# Altium schematic sheet mcu.SchDoc(U_mcu)
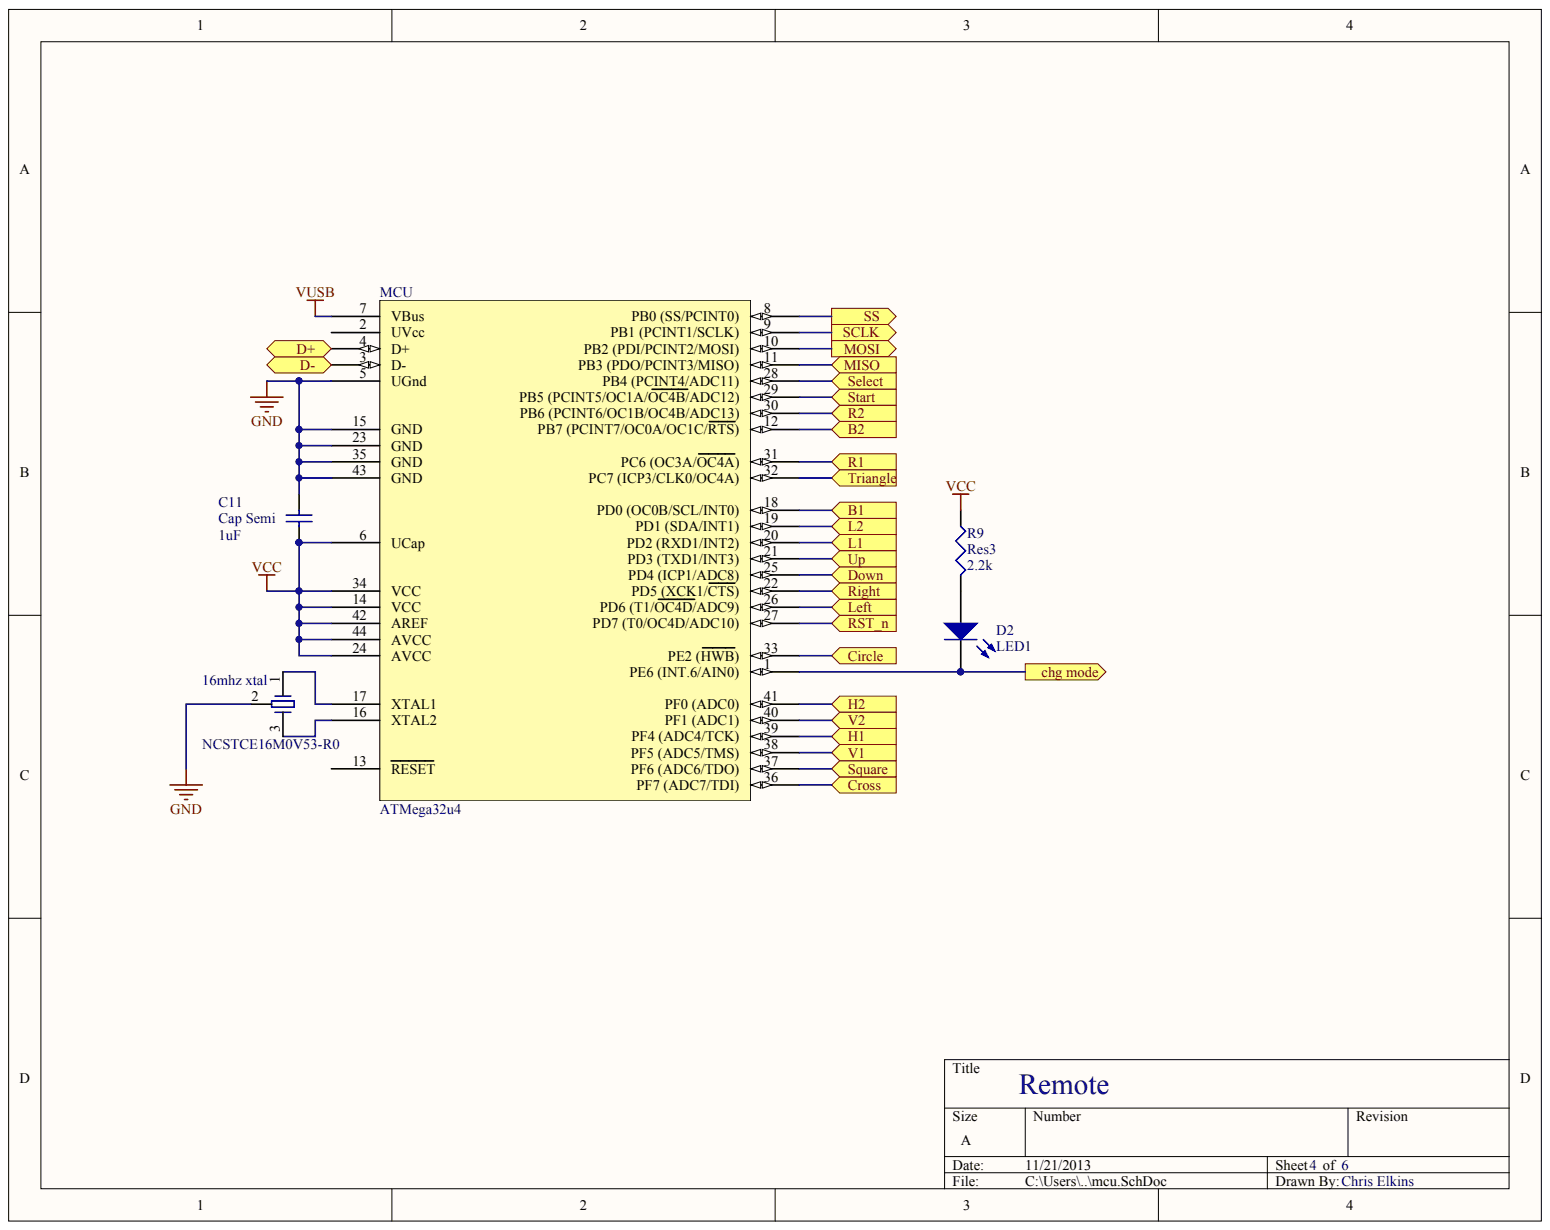
[diagram p0: mcu.SchDoc]

## Parts
16mhz_xtal  NCSTCE16M0V53-R0
C11  Cap Semi  value 1uF
D2  LED1
MCU  ATMega32u4
R9  Res3  value 2.2k

## Ports
B1, B2, CHG MODE, CIRCLE, CROSS, D+, D-, DOWN, H1, H2, L1, L2, LEFT, MISO, MOSI, R1, R2, RIGHT, RST_N, SCLK, SELECT, SQUARE, SS, START, TRIANGLE, UP, V1, V2

## Nets
GND: 16mhz_xtal.2 C11.2 MCU.5(UGnd) MCU.15(GND) MCU.23(GND) MCU.35(GND) MCU.43(GND)
VCC: C11.1 MCU.6(UCap) MCU.14(VCC) MCU.24(AVCC) MCU.34(VCC) MCU.42(AREF) MCU.44(AVCC) R9.1
VUSB: MCU.7(VBus)
B1: MCU.18(PD0_(OC0B/SCL/INT0)) ; port B1
B2: MCU.12 ; port B2
H1: MCU.39(PF4_(ADC4/TCK)) ; port H1
H2: MCU.41(PF0_(ADC0)) ; port H2
L1: MCU.20(PD2_(RXD1/INT2)) ; port L1
L2: MCU.19(PD1_(SDA/INT1)) ; port L2
MISO: MCU.11(PB3_(PDO/PCINT3/MISO)) ; port MISO
MOSI: MCU.10(PB2_(PDI/PCINT2/MOSI)) ; port MOSI
R1: MCU.31(PC6_(OC3A/OC4A)) ; port R1
R2: MCU.30(PB6_(PCINT6/OC1B/OC4B/ADC13)) ; port R2
SCLK: MCU.9(PB1_(PCINT1/SCLK)) ; port SCLK
SS: MCU.8(PB0_(SS/PCINT0)) ; port SS
V1: MCU.38(PF5_(ADC5/TMS)) ; port V1
V2: MCU.40(PF1_(ADC1)) ; port V2
Net16mhz xtal_1: 16mhz_xtal.1 MCU.17(XTAL1)
Net16mhz xtal_3: 16mhz_xtal.3 MCU.16(XTAL2)
NetD2_1: D2.1 R9.2
NetD2_2: D2.2 MCU.1(PE6_(INT.6/AIN0)) ; port CHG MODE
NetMCU_3: MCU.3(D-)
NetMCU_4: MCU.4(D+)
NetMCU_21: MCU.21(PD3_(TXD1/INT3)) ; port UP
NetMCU_22: MCU.22(PD5_(XCK1/CTS)) ; port RIGHT
NetMCU_25: MCU.25(PD4_(ICP1/ADC8)) ; port DOWN
NetMCU_26: MCU.26(PD6_(T1/OC4D/ADC9)) ; port LEFT
NetMCU_27: MCU.27(PD7_(T0/OC4D/ADC10)) ; port RST_N
NetMCU_28: MCU.28(PB4_(PCINT4/ADC11)) ; port SELECT
NetMCU_29: MCU.29(PB5_(PCINT5/OC1A/OC4B/ADC12)) ; port START
NetMCU_32: MCU.32(PC7_(ICP3/CLK0/OC4A)) ; port TRIANGLE
NetMCU_33: MCU.33(PE2_(HWB)) ; port CIRCLE
NetMCU_36: MCU.36(PF7_(ADC7/TDI)) ; port CROSS
NetMCU_37: MCU.37(PF6_(ADC6/TDO)) ; port SQUARE

Not connected: MCU 2(UVcc) 13(RESET)

## Library data of the parts
16mhz_xtal C11 D2 MCU R9 = (no symbol name)
  C11: Published: 8-Jun-2000; LatestRevisionDate: 29-May-2009; LatestRevisionNote: IPC-7351 Footprint Added.; PackageReference: C1206; PackageDescription: Chip Capacitor
  D2: Published: 8-Jun-2000; LatestRevisionDate: 08-Jul-2005; LatestRevisionNote: Mech Layer 1 removed.; PackageReference: LED-1
  R9: Published: 8-Jun-2000; LatestRevisionDate: 29-May-2009; LatestRevisionNote: IPC-7351 Footprint Added.; PackageReference: J1-0603; PackageDescription: Chip Resistor
  C11 D2 R9: Publisher: Altium Limited
